# Altium schematic sheet supply.SchDoc
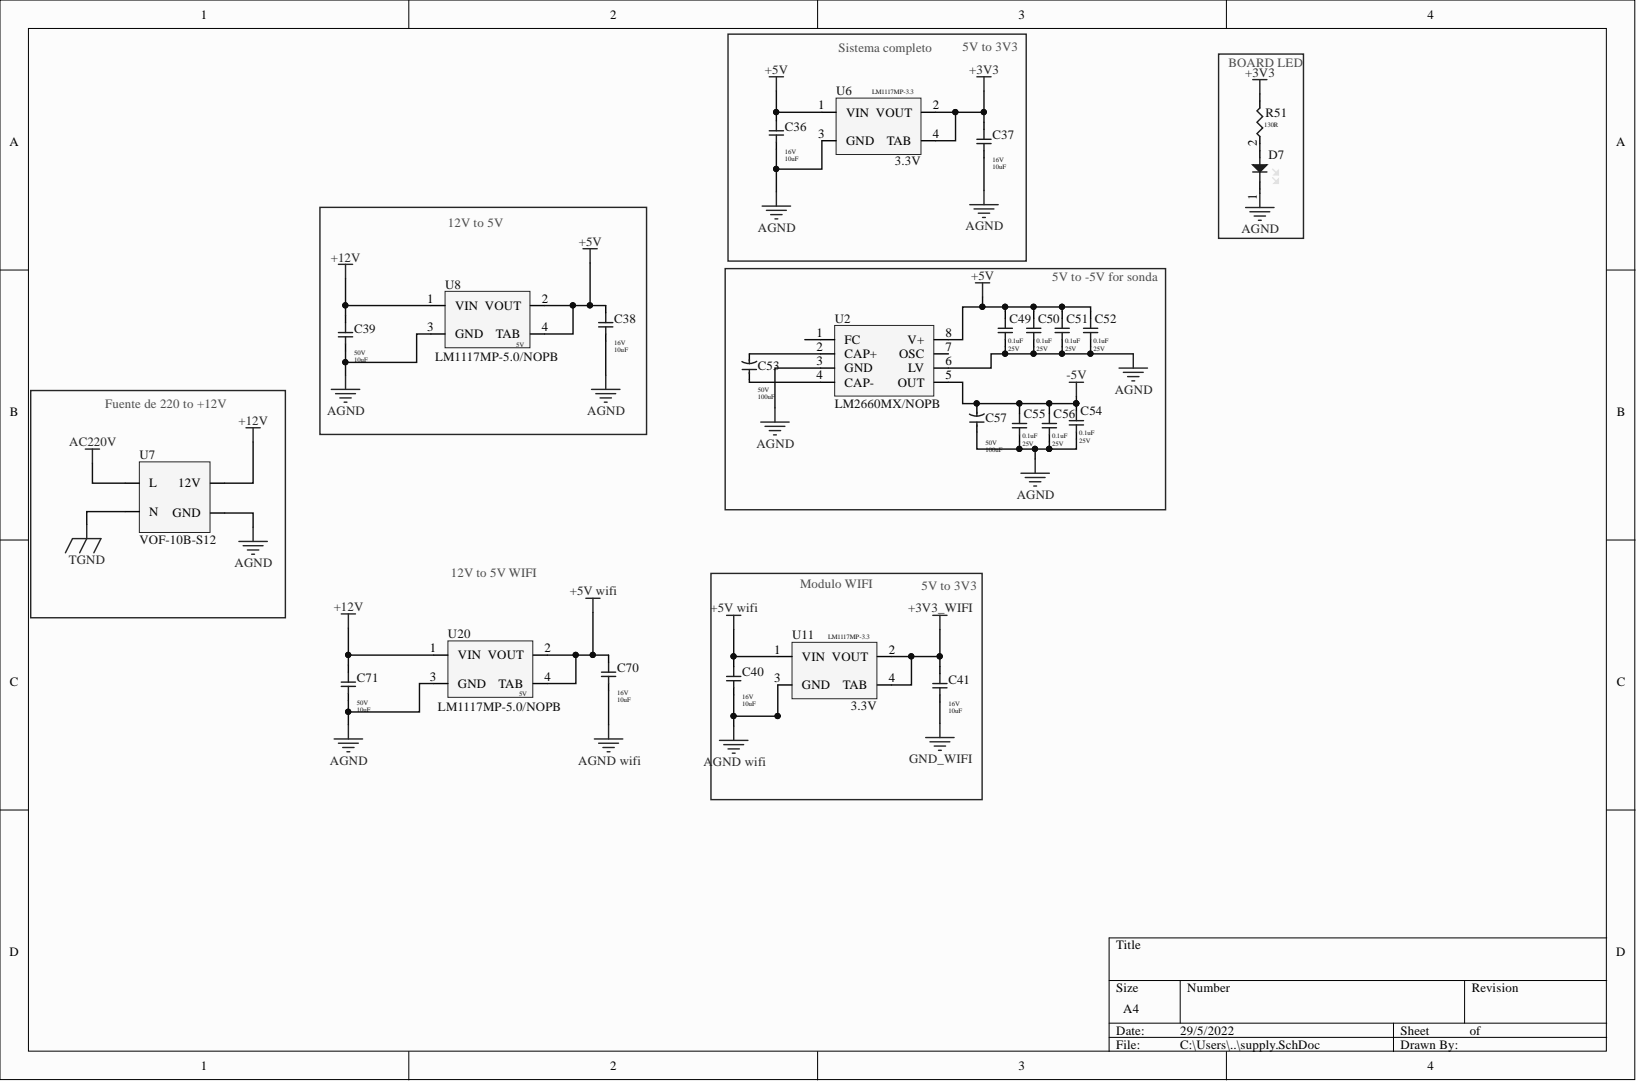
[diagram p0: supply.SchDoc]

## Parts
C36  Capacitance: 10uF  Voltage Rating: 16V  [CAP smd 0805 OK]  (hidden comment: CAP_CL21A106KOCL3RC)
C37  Capacitance: 10uF  Voltage Rating: 16V  [CAP smd 0805 OK]  (hidden comment: CAP_CL21A106KOCL3RC)
C38  Capacitance: 10uF  Voltage Rating: 16V  [CAP smd 0805 OK]  (hidden comment: CAP_CL21A106KOCL3RC)
C39  Capacitance: 10uF  Voltage Rating: 50V  [cap smd 1206 ok]  (hidden comment: CAP_CL31A106MBHNNNE)
C40  Capacitance: 10uF  Voltage Rating: 16V  [CAP smd 0805 OK]  (hidden comment: CAP_CL21A106KOCL3RC)
C41  Capacitance: 10uF  Voltage Rating: 16V  [CAP smd 0805 OK]  (hidden comment: CAP_CL21A106KOCL3RC)
C49  Capacitance: 0.1uF  Voltage Rating: 25V  [CAP smd 0805 ok]  (hidden comment: CAP_CC0805KRX7R8BB104_YAGEO)
C50  Capacitance: 0.1uF  Voltage Rating: 25V  [CAP smd 0805 ok]  (hidden comment: CAP_CC0805KRX7R8BB104_YAGEO)
C51  Capacitance: 0.1uF  Voltage Rating: 25V  [CAP smd 0805 ok]  (hidden comment: CAP_CC0805KRX7R8BB104_YAGEO)
C52  Capacitance: 0.1uF  Voltage Rating: 25V  [CAP smd 0805 ok]  (hidden comment: CAP_CC0805KRX7R8BB104_YAGEO)
C53  Capacitance: 100uF  Voltage: 50V  [cap elec 8x11.5 ok]  (hidden comment: CAP_ESY107M050AG3AA_KEMET)
C54  Capacitance: 0.1uF  Voltage Rating: 25V  [CAP smd 0805 ok]  (hidden comment: CAP_CC0805KRX7R8BB104_YAGEO)
C55  Capacitance: 0.1uF  Voltage Rating: 25V  [CAP smd 0805 ok]  (hidden comment: CAP_CC0805KRX7R8BB104_YAGEO)
C56  Capacitance: 0.1uF  Voltage Rating: 25V  [CAP smd 0805 ok]  (hidden comment: CAP_CC0805KRX7R8BB104_YAGEO)
C57  Capacitance: 100uF  Voltage: 50V  [cap elec 8x11.5 ok]  (hidden comment: CAP_ESY107M050AG3AA_KEMET)
C70  Capacitance: 10uF  Voltage Rating: 16V  [CAP smd 0805 OK]  (hidden comment: CAP_CL21A106KOCL3RC)
C71  Capacitance: 10uF  Voltage Rating: 50V  [cap smd 1206 ok]  (hidden comment: CAP_CL31A106MBHNNNE)
D7  150080YS75000  [Diode smd 0805 yellow ok]  (value not drawn)
R51  Resistance: 130R  [res 0805 ok]  (hidden comment: RC0805FR-07130RL)
U2  LM2660MX/NOPB  [LM2660MX/NOPB ok]
U6  LM1117MP-3.3  Max Output Voltage: 3.3V  [LM1117MP-3.3 ok]
U7  VOF-10B-S12  [12v]
U8  Nominal Output Voltage: 5V  [LM1117MP-5.0/NOPB ok]
U11  LM1117MP-3.3  Max Output Voltage: 3.3V  [LM1117MP-3.3 ok]
U20  Nominal Output Voltage: 5V  [LM1117MP-5.0/NOPB ok]

## Nets
+3V3: C37.23 R51.2 U6.2(VOUT) U6.4(TAB)
+3V3_WIFI: C41.23 U11.2(VOUT) U11.4(TAB)
+5V: C36.23 C38.23 C49.23 C50.23 C51.23 C52.23 U2.8(V+) U6.1(VIN) U8.2(VOUT) U8.4(TAB)
+5V wifi: C40.23 C70.23 U11.1(VIN) U20.2(VOUT) U20.4(TAB)
+12V: C39.23 C71.23 U7.3(12V) U8.1(VIN) U20.1(VIN)
-5V: C54.23 C55.23 C56.23 C57.25 U2.5(OUT)
AC220V: U7.2(L)
AGND: C36.22 C37.22 C38.22 C39.22 C49.22 C50.22 C51.22 C52.22 C54.22 C55.22 C56.22 C57.24 C71.22 D7.1 U2.3(GND) U2.6(LV) U6.3(GND) U7.4(GND) U8.3(GND) U20.3(GND)
AGND wifi: C40.22 C70.22 U11.3(GND)
GND_WIFI: C41.22
TGND: U7.1(N)
NetC53_24: C53.24 U2.4(CAP-)
NetC53_25: C53.25 U2.2(CAP+)
NetD7_2: D7.2 R51.1

Not connected: U2 1(FC) 7(OSC)

## Library data of the parts
C36-C38 C40 C41 C70 = CAP_CL21A106KOCL3RC: CAP CER 10UF 16V X5R 0805; Manufacturer 1: Samsung; Tolerance: 10%; Case/Package: 0805; Case Code (Imperial): 0805; Case Code (Metric): 2012; Component Kind: Standard; ComponentLink1Description: https://datasheet.ciiva.com/25796/cl21a106kocl3rc-25796224.pdf?src-supplier=Digi-Key; ComponentLink1URL: https://datasheet.ciiva.com/25796/cl21a106kocl3rc-25796224.pdf?src-supplier=Digi-Key; Length: 0.079inch; Library Name: Cap.SchLib; Manufacturer Part Number 1: CL21A106KOCL3RC; Max Operating Temperature: 85°C; Min Operating Temperature: -55°C; Mount: Surface Mount; Package Quantity: 1; Packaging: Tape and Reel; RoHS: Yes; RoHS Compliant: Yes; Series: CL; Stock 1: 0; Supplier 1: Digi-Key; Supplier Part Number 1: 1276-2398-2-ND; Thickness: 0.037inch; Width: 0.049inch
C39 C71 = CAP_CL31A106MBHNNNE: Cap Ceramic 10uF 50V X5R 20% Pad SMD 1206 85°C T/R; Manufacturer 1: Samsung; Case/Package: 1206; Case Code (Imperial): 1206; Case Code (Metric): 3216; Component Kind: Standard; ComponentLink1Description: http://www.samsungsem.com/kr/support/product-search/mlcc/CL31A106MBHNNNE.jsp?src-supplier=Digi-Key; ComponentLink1URL: http://www.samsungsem.com/kr/support/product-search/mlcc/CL31A106MBHNNNE.jsp?src-supplier=Digi-Key; Dielectric: X5R; Length: 0.126inch; Library Name: Cap.SchLib; Manufacturer Part Number 1: CL31A106MBHNNNE; Max Operating Temperature: 85°C; Min Operating Temperature: -55°C; Mount: Surface Mount; Packaging: Tape and Reel; Pricing 1: 1=0,31000, 10=0,21300, 50=0,14200, 100=0,12070, 500=0,08520, 1000=0,07455 (USD); RoHS: Yes; RoHS Compliant: Yes; Stock 1: 1423422; Supplier 1: Digi-Key; Supplier Part Number 1: 1276-6736-1-ND; Thickness: 0.071inch; Tolerance: 20%; Voltage Rating (DC): 50V; Width: 0.063inch
C49-C52 C54-C56 = CAP_CC0805KRX7R8BB104_YAGEO: CAPACITOR, 0805, 100NF, 25V, REEL 4000; Manufacturer 1: Yageo; Dielectric: X7R; Insulation Resistance: 100GR; Material: Ceramic; Tolerance: 10%; Case/Package: 0805; Case Code (Imperial): 0805; Case Code (Metric): 2012; Component Kind: Standard; ComponentLink1Description: https://datasheet.ciiva.com/8034/70765-8034031.pdf?src-supplier=Element14; ComponentLink1URL: https://datasheet.ciiva.com/8034/70765-8034031.pdf?src-supplier=Element14; ComponentLink2Description: https://datasheet.ciiva.com/28450/1489800-28450789.pdf?src-supplier=Element14; ComponentLink2URL: https://datasheet.ciiva.com/28450/1489800-28450789.pdf?src-supplier=Element14; ComponentLink3Description: https://datasheet.ciiva.com/46430/1850775-46430166.pdf?src-supplier=Element14; ComponentLink3URL: https://datasheet.ciiva.com/46430/1850775-46430166.pdf?src-supplier=Element14; ComponentLink4Description: https://datasheet.ciiva.com/145/mlccorderingcode-145008.pdf?src-supplier=Digi-Key; ComponentLink4URL: https://datasheet.ciiva.com/145/mlccorderingcode-145008.pdf?src-supplier=Digi-Key; ComponentLink5Description: https://datasheet.ciiva.com/145/mlccorderingcode-145007.pdf?src-supplier=Digi-Key; ComponentLink5URL: https://datasheet.ciiva.com/145/mlccorderingcode-145007.pdf?src-supplier=Digi-Key; ComponentLink6Description: https://datasheet.ciiva.com/145/mlccorderingcode-145009.pdf?src-supplier=Digi-Key; ComponentLink6URL: https://datasheet.ciiva.com/145/mlccorderingcode-145009.pdf?src-supplier=Digi-Key; ComponentLink7Description: https://datasheet.ciiva.com/8034/1784427-8034030.pdf?src-supplier=Element14; ComponentLink7URL: https://datasheet.ciiva.com/8034/1784427-8034030.pdf?src-supplier=Element14; ComponentLink8Description: https://datasheet.ciiva.com/8034/1784427-8034029.pdf?src-supplier=Element14; ComponentLink8URL: https://datasheet.ciiva.com/8034/1784427-8034029.pdf?src-supplier=Element14; ComponentLink9Description: https://datasheet.ciiva.com/15189/getdatasheetpartid-330244-15189223.pdf?src-supplier=Verical; ComponentLink9URL: https://datasheet.ciiva.com/15189/getdatasheetpartid-330244-15189223.pdf?src-supplier=Verical; ComponentLink10Description: https://datasheet.ciiva.com/16625/upy-gphc-x7r-6-3v-to-50v-8-16625546.pdf?src-supplier=Avnet+Europe; ComponentLink10URL: https://datasheet.ciiva.com/16625/upy-gphc-x7r-6-3v-to-50v-8-16625546.pdf?src-supplier=Avnet+Europe; Depth: 1.25mm; Halogen Free: Halogen Free; Height: 0.85mm; Lead Free: Lead Free; Length: 2mm; Library Name: Cap.SchLib; Manufacturer Part Number 1: CC0805KRX7R8BB104; Max Operating Temperature: 125°C; Min Operating Temperature: -55°C; Mount: Surface Mount; Number of Pins: 2; Package Quantity: 1; Packaging: Tape and Reel; Pricing 1: 1=0,12000, 10=0,08800, 50=0,04980, 100=0,04130, 500=0,02894, 1000=0,02396 (USD); Radiation Hardening: No; REACH SVHC: No SVHC; Resistor Type: Ceramic; RoHS: Yes; RoHS Compliant: Yes; Series: CC; Stock 1: 278639; Supplier 1: Digi-Key; Supplier Part Number 1: 311-1141-1-ND; Termination: SMD/SMT; Thickness: 0.033inch; Voltage Rating (DC): 25V; Width: 0.049inch
C53 C57 = CAP_ESY107M050AG3AA_KEMET: KEMET - ESY107M050AG3AA - Elektrolytkondensator, 100 µF, 50 V, Produktreihe ESY, ± 20%, Radial bedrahtet, 8 mm; Dielectric Material: Aluminium; Dissipation Factor: 0.1%; ESR (Equivalent Series Resistance): 74mR; Lead/Base Style: Straight; Lead Diameter: 0.6mm; Lead Length: 20mm; Leakage Current: 50uA; Life (Hours): 3000Hour; Ripple Current: 511mA; Case/Package: Radial; Component Kind: Standard; ComponentLink1Description: http://www.farnell.com/datasheets/2751412.pdf?src-supplier=Farnell; ComponentLink1URL: http://www.farnell.com/datasheets/2751412.pdf?src-supplier=Farnell; ComponentLink2Description: http://www.mouser.com/ds/2/212/KEM_A4007_ESY-1103537.pdf?src-supplier=Mouser; ComponentLink2URL: http://www.mouser.com/ds/2/212/KEM_A4007_ESY-1103537.pdf?src-supplier=Mouser; ComponentLink3Description: http://components.arrow.com/part/dataview/0323_06946698?src-supplier=Arrow+Europe; ComponentLink3URL: http://components.arrow.com/part/dataview/0323_06946698?src-supplier=Arrow+Europe; ComponentLink4Description: https://www.alliedelec.com/m/d/2f7883d23207e2a46fd2b27bea013c02.pdf?src-supplier=Allied+Electronics; ComponentLink4URL: https://www.alliedelec.com/m/d/2f7883d23207e2a46fd2b27bea013c02.pdf?src-supplier=Allied+Electronics; ComponentLink5Description: http://uk.rs-online.com/webdocs/13da/0900766b813da6ab.pdf?src-supplier=RSComponents; ComponentLink5URL: http://uk.rs-online.com/webdocs/13da/0900766b813da6ab.pdf?src-supplier=RSComponents; ComponentLink6Description: https://api.kemet.com/component-edge/download/specsheet/ESY107M050AG3AA.pdf?src-supplier=Digi-Key; ComponentLink6URL: https://api.kemet.com/component-edge/download/specsheet/ESY107M050AG3AA.pdf?src-supplier=Digi-Key; ComponentLink7Description: http://capacitoredge.kemet.com/capedge2/DataSheet?pn=ESY107M050AG3AA&src-supplier=Avnet; ComponentLink7URL: http://capacitoredge.kemet.com/capedge2/DataSheet?pn=ESY107M050AG3AA&src-supplier=Avnet; ComponentLink8Description: http://capacitoredge.kemet.com/capedge2/DataSheet/Datasheet-ESY107M050AG3AA.pdf?pn=ESY107M050AG3AA&src-supplier=Onlinecomponents.com; ComponentLink8URL: http://capacitoredge.kemet.com/capedge2/DataSheet/Datasheet-ESY107M050AG3AA.pdf?pn=ESY107M050AG3AA&src-supplier=Onlinecomponents.com; ComponentLink9Description: http://capacitoredge.kemet.com/capedge2/DataSheet?pn=ESY107M050AG3AA&src-supplier=Avnet+Europe; ComponentLink9URL: http://capacitoredge.kemet.com/capedge2/DataSheet?pn=ESY107M050AG3AA&src-supplier=Avnet+Europe; ComponentLink10Description: http://www.farnell.com/datasheets/2751412.pdf?src-supplier=Newark; ComponentLink10URL: http://www.farnell.com/datasheets/2751412.pdf?src-supplier=Newark; Diameter: 8mm; Height: 11mm; Height - Seated (Max): 0.492inch; Impedance: 74mR; Lead Pitch: 3.5mm; Length: 11mm; Library Name: Cap.SchLib; Manufacturer 1: KEMET; Manufacturer Part Number 1: ESY107M050AG3AA; Max Operating Temperature: 105°C; Min Operating Temperature: -40°C; Mount: Through Hole,PCB; Package Quantity: 6000; Packaging: Bulk; Pricing 1: 1=0,44000, 10=0,30700, 50=0,21500, 100=0,18420, 500=0,13818, 1000=0,11668, 2500=0,11054, 5000=0,10440 (USD); Radiation Hardening: No; RoHS: Yes; RoHS Compliant: Yes; Series: ESY; Stock 1: 587; Supplier 1: Digi-Key; Supplier Part Number 1: 399-6119-ND; Termination: Radial; Tolerance: 20%; Voltage Rating: 50V; Voltage Rating (DC): 50V
D7 = 150080YS75000: LED YELLOW CLEAR 0805 SMD; Manufacturer 1: Wurth Electronics; Dominant Wavelength: 590nm; Forward Current: 30mA; Forward Voltage: 2V; Lens Style: Clear,Rectangular; Luminous Intensity: 120mcd; Test Current: 20mA; Viewing Angle: 140°; Case/Package: 0805; Case Code (Imperial): 0805; Case Code (Metric): 2012; Color: Yellow; Component Kind: Standard; ComponentLink1Description: https://datasheet.ciiva.com/23843/150080ys75000-23843256.pdf?src-supplier=Newark; ComponentLink1URL: https://datasheet.ciiva.com/23843/150080ys75000-23843256.pdf?src-supplier=Newark; ComponentLink2Description: https://datasheet.ciiva.com/29279/759438-da-01-en-chipled-0805-w-kl-gelb-150080ys75000-29279034.pdf?src-supplier=Conrad; ComponentLink2URL: https://datasheet.ciiva.com/29279/759438-da-01-en-chipled-0805-w-kl-gelb-150080ys75000-29279034.pdf?src-supplier=Conrad; ComponentLink3Description: https://datasheet.ciiva.com/29563/150080ys75000-29563019.pdf?src-supplier=Digi-Key; ComponentLink3URL: https://datasheet.ciiva.com/29563/150080ys75000-29563019.pdf?src-supplier=Digi-Key; ComponentLink4Description: https://datasheet.ciiva.com/29563/150080ys75000-29563020.pdf?src-supplier=Digi-Key; ComponentLink4URL: https://datasheet.ciiva.com/29563/150080ys75000-29563020.pdf?src-supplier=Digi-Key; ComponentLink5Description: https://datasheet.ciiva.com/29563/150080ys75000-29563021.pdf?src-supplier=Digi-Key; ComponentLink5URL: https://datasheet.ciiva.com/29563/150080ys75000-29563021.pdf?src-supplier=Digi-Key; ComponentLink6Description: https://datasheet.ciiva.com/22656/1911353-22656971.pdf?src-supplier=Element14; ComponentLink6URL: https://datasheet.ciiva.com/22656/1911353-22656971.pdf?src-supplier=Element14; Height: 0.7mm; Length: 2mm; Library Name: diode.SchLib; Manufacturer Part Number 1: 150080YS75000; Max Operating Temperature: 85°C; Min Operating Temperature: -40°C; Mount: Surface Mount; Package Quantity: 4000; Packaging: Tape and Reel; Pricing 1: 500=0,09600, 750=0,09200, 1500=0,08700, 3000=0,08300 (GBP); REACH SVHC: Unknown; RoHS: Yes; RoHS Compliant: Yes; Stock 1: 9250; Supplier 1: RSComponents; Supplier Part Number 1: 8154231P; Wavelength: 590nm; Width: 1.25mm
R51 = RC0805FR-07130RL: Res Thick Film smd 0805 130 Ohm 1% 0.125W(; Manufacturer 1: Yageo; Max Power Dissipation: 125mW; Temperature Coefficient: 100ppm/°C; Voltage Rating: 150V; Case/Package: 0805; Case Code (Imperial): 0805; Case Code (Metric): 2012; Component Kind: Standard; ComponentLink1Description: https://datasheet.ciiva.com/11808/0900766b8106beab-11808374.pdf?src-supplier=RSComponents; ComponentLink1URL: https://datasheet.ciiva.com/11808/0900766b8106beab-11808374.pdf?src-supplier=RSComponents; ComponentLink2Description: https://datasheet.ciiva.com/2457/pyu-r-int-thick-7-2457546.pdf?src-supplier=Digi-Key; ComponentLink2URL: https://datasheet.ciiva.com/2457/pyu-r-int-thick-7-2457546.pdf?src-supplier=Digi-Key; ComponentLink3Description: https://datasheet.ciiva.com/2457/pyu-r-int-thick-7-2457547.pdf?src-supplier=Digi-Key; ComponentLink3URL: https://datasheet.ciiva.com/2457/pyu-r-int-thick-7-2457547.pdf?src-supplier=Digi-Key; ComponentLink4Description: https://datasheet.ciiva.com/2457/pyu-r-int-thick-7-2457545.pdf?src-supplier=Digi-Key; ComponentLink4URL: https://datasheet.ciiva.com/2457/pyu-r-int-thick-7-2457545.pdf?src-supplier=Digi-Key; ComponentLink5Description: https://datasheet.ciiva.com/15189/getdatasheetpartid-326400-15189899.pdf?src-supplier=Verical; ComponentLink5URL: https://datasheet.ciiva.com/15189/getdatasheetpartid-326400-15189899.pdf?src-supplier=Verical; ComponentLink6Description: https://datasheet.ciiva.com/16746/rc0805-16746879.pdf?src-supplier=Future+Electronics; ComponentLink6URL: https://datasheet.ciiva.com/16746/rc0805-16746879.pdf?src-supplier=Future+Electronics; Construction: Rectangular; Depth: 1.25mm; Features: Moisture Resistant; Height: 0.5mm; Lead Free: Lead Free; Length: 2mm; Library Name: res.SchLib; Manufacturer Part Number 1: RC0805FR-07130RL; Max Operating Temperature: 155°C; Military Standard: Not; Min Operating Temperature: -55°C; Mount: Surface Mount; Number of Terminations: 2; Package Quantity: 1; Packaging: Tape and Reel; Power Rating: 125mW; Pricing 1: 1=0,10000, 10=0,04200, 100=0,01690, 1000=0,00759, 2500=0,00659 (USD); Resistor Type: Thick Film; RoHS: Yes; RoHS Compliant: Yes; Series: RC; Size Code: 0805; Stock 1: 289647; Supplier 1: Digi-Key; Supplier Part Number 1: 311-130CRCT-ND; Technology: THICK FILM; Termination: SMD/SMT; Tolerance: 1%; Voltage Rating (DC): 150V; Width: 0.049inch
U2 = LM2660MX/NOPB: Charge Pump INV/STPUP -1.5V to -5.5V 120mA 8-Pin SOIC T/R; Manufacturer 1: TI National Semiconductor; Logic Function: Inverting; Max Input Voltage: 5.5V; Min Input Voltage: 1.5V; Number of Outputs: 1; Operating Supply Current: 16mA; Output Current: 100mA; Quiescent Current: 1mA; Switching Frequency: 40kHz; Synchronous Rectifier: No; Case/Package: SOIC; Component Kind: Standard; ComponentLink1Description: https://datasheet.ciiva.com/26909/getdatasheetpartid-767710-26909758.pdf?src-supplier=Verical; ComponentLink1URL: https://datasheet.ciiva.com/26909/getdatasheetpartid-767710-26909758.pdf?src-supplier=Verical; ComponentLink2Description: https://datasheet.ciiva.com/6594/lm2660-6594222.pdf?src-supplier=Digi-Key; ComponentLink2URL: https://datasheet.ciiva.com/6594/lm2660-6594222.pdf?src-supplier=Digi-Key; Contact Plating: Tin,Matte; Lead Free: Lead Free; Library Name: ic.SchLib; Manufacturer Part Number 1: LM2660MX/NOPB; Max Operating Temperature: 85°C; Max Supply Voltage: 5.5V; Min Operating Temperature: -40°C; Min Supply Voltage: 1.5V; Mount: Surface Mount; Number of Pins: 8; Output Voltage: -5.5V; Packaging: Tape and Reel; Pricing 1: 1=1,66000, 10=1,49400, 25=1,40960, 100=1,20110, 250=1,12784, 500=0,98686, 1000=0,93100 (USD); Radiation Hardening: No; RoHS: Yes; RoHS Compliant: Yes; Stock 1: 13332; Supplier 1: Digi-Key; Supplier Part Number 1: LM2660MX/NOPBCT-ND; Weight: 0.006596oz
U6 U11 = LM1117MP-3.3: LDO Regulator Pos 3.3V 0.8A 4-Pin(3+Tab) SOT-223 T/R; Manufacturer 1: TI National Semiconductor; Accuracy: 1%; Dropout Voltage: 1.2V; Max Input Voltage: 15V; Max Output Current: 800mA; Max Supply Voltage: 10V; Min Input Voltage: 4.5V; Min Supply Voltage: 4.75V; Number of Outputs: 1; Output Type: Fixed; Polarity: Positive; Quiescent Current: 10mA; Case/Package: SOT-223; Component Kind: Standard; ComponentLink1Description: https://datasheet.ciiva.com/1511/1685500-1511708.pdf?src-supplier=Element14; ComponentLink1URL: https://datasheet.ciiva.com/1511/1685500-1511708.pdf?src-supplier=Element14; ComponentLink2Description: https://datasheet.ciiva.com/26904/lm1117mp-3-3-26904890.pdf?src-supplier=IIIC; ComponentLink2URL: https://datasheet.ciiva.com/26904/lm1117mp-3-3-26904890.pdf?src-supplier=IIIC; ComponentLink3Description: https://datasheet.ciiva.com/14275/lm1117-n-14275231.pdf?src-supplier=Avnet; ComponentLink3URL: https://datasheet.ciiva.com/14275/lm1117-n-14275231.pdf?src-supplier=Avnet; ComponentLink4Description: https://datasheet.ciiva.com/1511/1685500-1511709.pdf?src-supplier=Element14; ComponentLink4URL: https://datasheet.ciiva.com/1511/1685500-1511709.pdf?src-supplier=Element14; Library Name: ic.SchLib; Manufacturer Part Number 1: LM1117MP-3.3; Max Operating Temperature: 125°C; Min Operating Temperature: 0°C; Mount: Surface Mount; Nominal Output Voltage: 3.3V; Number of Pins: 4; Output Current: 800mA; Output Voltage: 3.3V; Output Voltage Accuracy: 1%; Packaging: Tape and Reel; Radiation Hardening: No; REACH SVHC: No SVHC; RoHS: No; RoHS Compliant: No; Stock 1: 0; Supplier 1: Arrow Electronics; Supplier Part Number 1: LM1117MP-3.3; Voltage: 3.3V
U7 = VOF-10B-S12: AC/DC CONVERTER 12V 10W; Manufacturer 1: CUI; Approvals: CE,cURus; Efficiency: 82.32%; Max Output Current: 850mA; Number of Outputs: 1; Component Kind: Standard; ComponentLink1Description: https://www.cui.com/product/resource/digikeypdf/vof-10b.pdf?src-supplier=Digi-Key; ComponentLink1URL: https://www.cui.com/product/resource/digikeypdf/vof-10b.pdf?src-supplier=Digi-Key; Library Name: others.SchLib; Manufacturer Part Number 1: VOF-10B-S12; Max Operating Temperature: 70°C; Max Power Dissipation: 10W; Min Operating Temperature: -25°C; Mount: Through Hole; Pricing 1: 1=12,02000, 5=11,85000, 10=11,67900, 25=10,99200, 50=10,64840, 100=10,30500, 250=9,96152, 500=9,61800 (USD); RoHS: Yes; RoHS Compliant: Yes; Stock 1: 0; Supplier 1: Digi-Key; Supplier Part Number 1: 102-4145-ND; Voltage - Output 1: 12V
U8 U20 = LM1117MP-5.0/NOPB: Space saving 800-mA low-dropout linear regulator with internal current limit 4-SOT-223 0 to 125; Manufacturer 1: TI National Semiconductor; Accuracy: 1%; Current Rating: 800A; Dropout Voltage: 1.2V; Max Input Voltage: 15V; Max Output Current: 800mA; Max Supply Current: 10mA; Max Supply Voltage: 12V; Min Input Voltage: 2.6V; Number of Outputs: 1; Output Configuration: Positive; Output Type: Fixed; Power Supply Rejection Ratio (PSRR): 75dB; Quiescent Current: 5mA; Case/Package: SOT-223; Component Kind: Standard; ComponentLink1Description: https://datasheet.ciiva.com/15111/getdatasheetpartid-68363-15111331.pdf?src-supplier=Verical; ComponentLink1URL: https://datasheet.ciiva.com/15111/getdatasheetpartid-68363-15111331.pdf?src-supplier=Verical; ComponentLink2Description: https://datasheet.ciiva.com/14149/lm1117-eng-tds-14149981.pdf?src-supplier=Distrelec; ComponentLink2URL: https://datasheet.ciiva.com/14149/lm1117-eng-tds-14149981.pdf?src-supplier=Distrelec; ComponentLink3Description: https://datasheet.ciiva.com/25980/lm1117-n-25980317.pdf?src-supplier=Avnet+Europe; ComponentLink3URL: https://datasheet.ciiva.com/25980/lm1117-n-25980317.pdf?src-supplier=Avnet+Europe; ComponentLink4Description: https://datasheet.ciiva.com/6580/lm1117-n-6580058.pdf?src-supplier=Digi-Key; ComponentLink4URL: https://datasheet.ciiva.com/6580/lm1117-n-6580058.pdf?src-supplier=Digi-Key; ComponentLink5Description: https://datasheet.ciiva.com/6580/lm1117-n-6580053.pdf?src-supplier=Digi-Key; ComponentLink5URL: https://datasheet.ciiva.com/6580/lm1117-n-6580053.pdf?src-supplier=Digi-Key; Contact Plating: Tin,Matte; Height: 1.6mm; Lead Free: Lead Free; Length: 6.5mm; Library Name: ic.SchLib; Manufacturer Part Number 1: LM1117MP-5.0/NOPB; Max Operating Temperature: 125°C; Min Current Limit: 800mA; Min Operating Temperature: 0°C; Mount: Surface Mount; Number of Pins: 4; Number of Regulators: 1; Output Current: 800mA; Output Voltage: 5V; Output Voltage Accuracy: 1%; Package Quantity: 1000; Packaging: Tape and Reel; Polarity: Positive; Pricing 1: 1=1,08320, 1000=0,48480 (USD); Radiation Hardening: No; REACH SVHC: No SVHC; RoHS: Yes; RoHS Compliant: Yes; Series: -; Stock 1: 2; Supplier 1: Arrow Electronics; Supplier Part Number 1: LM1117MP-5.0/NOPB; Width: 3.56mm
